# Altium schematic sheet Sheet8.SchDoc(Sheet8)
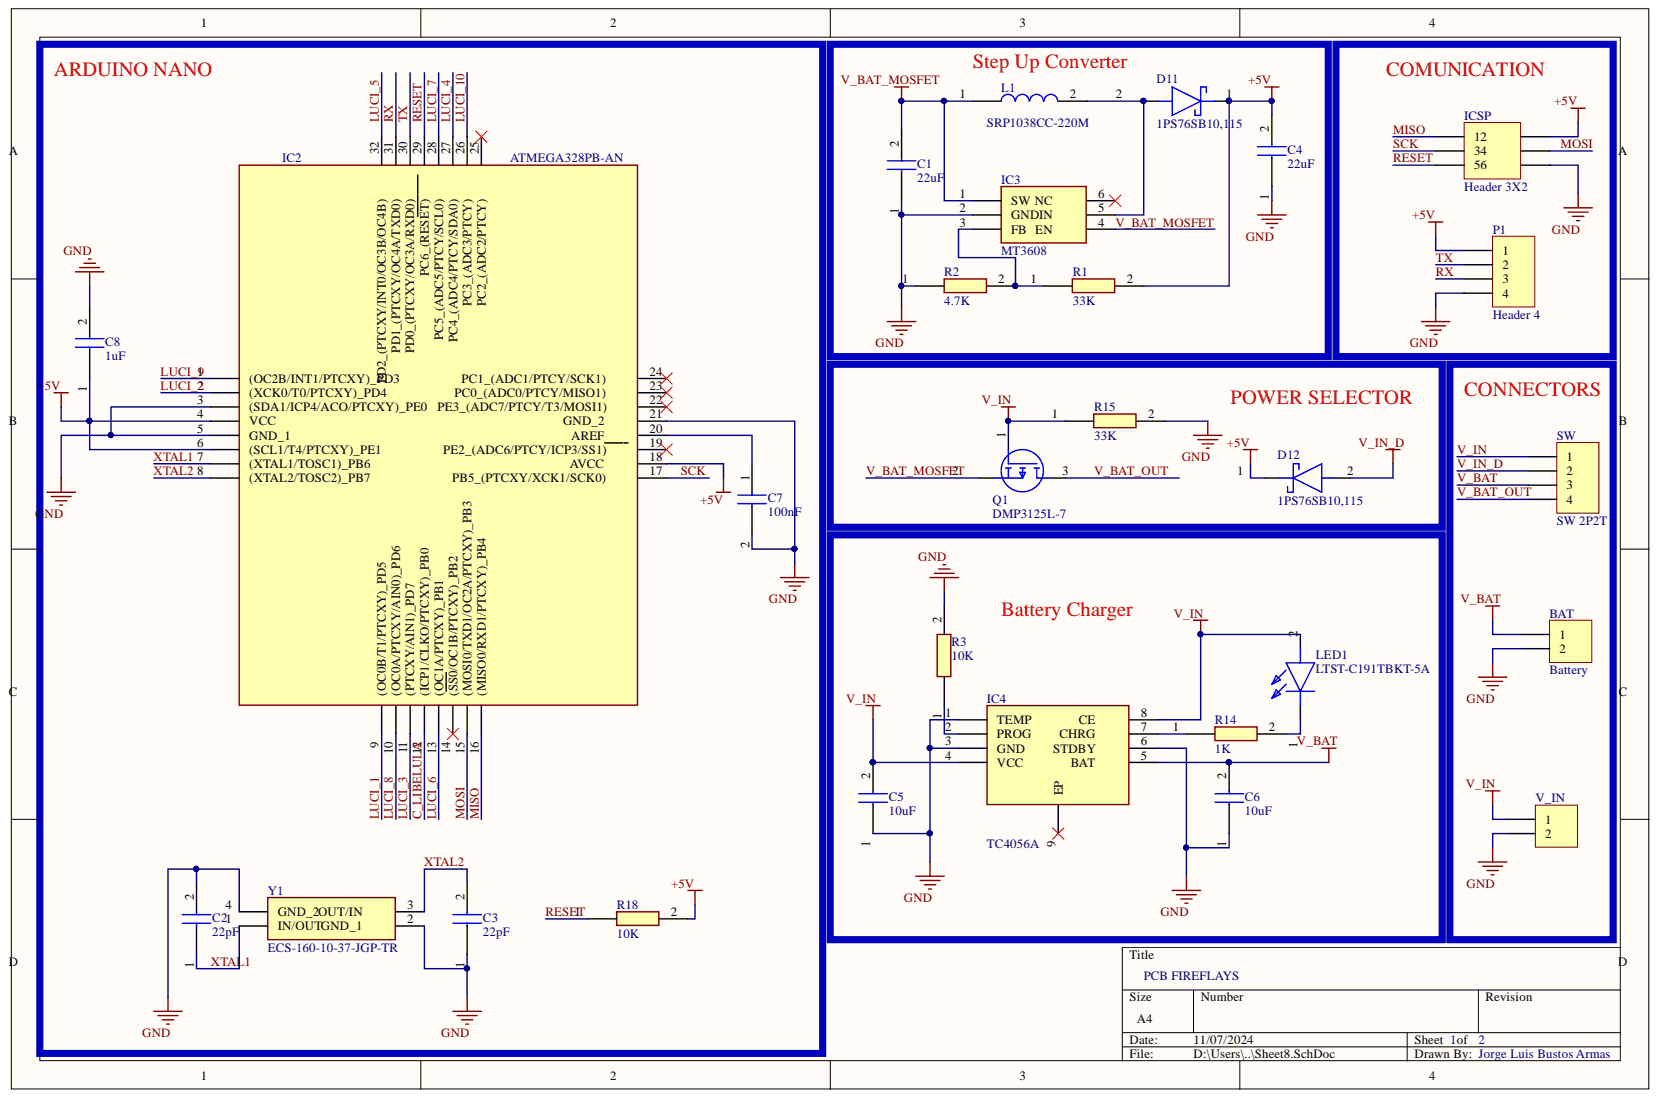
[diagram p0: Sheet8.SchDoc]

## Parts
BAT  Battery  [HDR1X2]
C1  22uF  [CAPC1608X90N]
C2  22pF  [CAPC1608X90N]
C3  22pF  [CAPC1608X90N]
C4  22uF  [CAPC1608X90N]
C5  10uF  [CAPC1608X90N]
C6  10uF  [CAPC1608X90N]
C7  100nF  [CAPC1608X90N]
C8  1uF  [CAPC1608X90N]
D11  1PS76SB10,115  [SOD2512X110N]
D12  1PS76SB10,115  [SOD2512X110N]
IC2  ATMEGA328PB-AN  [QFP80P900X900X120-32N]
IC3  MT3608  [SOT95P280X145-6N]
IC4  TC4056A  [SOIC127P600X175-9N]
ICSP  Header 3X2  [HDR2X3]  (no BOM)
L1  SRP1038CC-220M  [INDPM110100X400N]
LED1  LTST-C191TBKT-5A  [LEDC1608X60N]
P1  Header 4  [HDR1X4]  (no BOM)
Q1  DMP3125L-7  [SOT96P240X110-3N]
R1  33K  [RESC1608X55N]
R2  4.7K  [RESC1608X55N]
R3  10K  [RESC1608X55N]
R14  1K  [RESC1608X55N]
R15  33K  [RESC1608X55N]
R18  10K  [RESC1608X55N]
SW  SW 2P2T  [HDR1X4]
V_IN  Type C female connector  [HDR1X2]  (value not drawn)
Y1  ECS-160-10-37-JGP-TR  [ECS1601037JGPTR]

## Nets
+5V: C4.2 C8.1 D11.1 D12.1 IC2.4(VCC) IC2.6((SCL1/T4/PTCXY)_PE1) IC2.18 ICSP.2 P1.1 R1.2 R18.2
GND: BAT.2 C1.1 C2.2 C3.1 C4.1 C5.1 C6.1 C7.2 C8.2 IC2.3((SDA1/ICP4/ACO/PTCXY)_PE0) IC2.5(GND_1) IC2.21 IC3.2 IC4.1(TEMP) IC4.3(GND) IC4.6 ICSP.6 P1.4 R2.1 R3.2 R15.2 V_IN.2 Y1.2 Y1.4(GND_2)
C_LIBELULA: IC2.12((ICP1/CLKO/PTCXY)_PB0)
LUCI_1: IC2.9((OC0B/T1/PTCXY)_PD5)
LUCI_2: IC2.2((XCK0/T0/PTCXY)_PD4)
LUCI_3: IC2.11((PTCXY/AIN1)_PD7)
LUCI_4: IC2.27
LUCI_5: IC2.32
LUCI_6: IC2.13((OC1A/PTCXY)_PB1)
LUCI_7: IC2.28
LUCI_8: IC2.10((OC0A/PTCXY/AIN0)_PD6)
LUCI_9: IC2.1((OC2B/INT1/PTCXY)_PD3)
LUCI_10: IC2.26
MISO: IC2.16((MISO0/RXD1/PTCXY)_PB4) ICSP.1
MOSI: IC2.15((MOSI0/TXD1/OC2A/PTCXY)_PB3) ICSP.4
RESET: IC2.29 ICSP.5 R18.1
RX: IC2.31 P1.3
SCK: IC2.17 ICSP.3
TX: IC2.30 P1.2
V_BAT: BAT.1 C6.2 IC4.5 SW.3
V_BAT_MOSFET: C1.2 IC3.1(SW_NC) IC3.4 L1.1 Q1.2
V_BAT_OUT: Q1.3 SW.4
V_IN: C5.2 IC4.4(VCC) IC4.8 LED1.2 Q1.1 R15.1 SW.1 V_IN.1
V_IN_D: D12.2 SW.2
XTAL1: C2.1 IC2.7((XTAL1/TOSC1)_PB6) Y1.1(IN/OUT)
XTAL2: C3.2 IC2.8((XTAL2/TOSC2)_PB7) Y1.3
NetC7_1: C7.1 IC2.20
NetD11_2: D11.2 IC3.5 L1.2
NetIC3_3: IC3.3(FB) R1.1 R2.2
NetIC4_2: IC4.2(PROG) R3.1
NetIC4_7: IC4.7 R14.1
NetLED1_1: LED1.1 R14.2

Not connected: IC2 14((SS0/OC1B/PTCXY)_PB2) 19 22 23 24 25; IC3 6; IC4 9(EP)

## Library data of the parts
BAT = Header 2: Li-Po battery 400 mAh; Component Kind: Standard; LatestRevisionDate: 17-Jul-2002; LatestRevisionNote: Re-released for DXP Platform.; Library Name: Miscellaneous Connectors.IntLib; Signal Integrity: Connector; Publisher: Altium Limited
C1-C8 = 06035C105KAT2A: Capacitor; Manufacturer_Name: Kyocera AVX; Arrow Part Number: 06035C105KAT2A; Arrow Price/Stock: https://www.arrow.com/en/products/06035c105kat2a/avx?region=nac; Component Kind: Standard; Datasheet Link: https://componentsearchengine.com/Datasheets/1/06031A100FAT2A.pdf; Height: 0.9mm; Manufacturer_Part_Number: 06035C105KAT2A; Mouser Part Number: 581-06035C105KAT2A; Mouser Price/Stock: https://www.mouser.co.uk/ProductDetail/KYOCERA-AVX/06035C105KAT2A?qs=%252BdQmOuGyFcGCdIIWh6fU7Q%3D%3D
D11 D12 = 1PS76SB10,115: Schottky Diode; Manufacturer_Name: Nexperia; Arrow Part Number: 1PS76SB10,115; Arrow Price/Stock: https://www.arrow.com/en/products/1ps76sb10115/nexperia?region=europe; Component Kind: Standard; Datasheet Link: https://assets.nexperia.com/documents/data-sheet/1PS76SB10.pdf; Height: 1.1mm; Library Name: SamacSys.SchLib; Manufacturer_Part_Number: 1PS76SB10,115; Mouser Part Number: 771-1PS76SB10-T/R; Mouser Price/Stock: https://www.mouser.co.uk/ProductDetail/Nexperia/1PS76SB10115?qs=P62ublwmbi%252BteaMAe9dhAQ%3D%3D
IC2 = ATMEGA328PB-AN: Integrated Circuit; Manufacturer_Name: Microchip; Arrow Part Number: ATMEGA328PB-AN; Arrow Price/Stock: https://www.arrow.com/en/products/atmega328pb-an/microchip-technology?region=nac; Component Kind: Standard; Datasheet Link: http://ww1.microchip.com/downloads/en/DeviceDoc/40001906C.pdf; Height: 1.2mm; Manufacturer_Part_Number: ATMEGA328PB-AN; Mouser Part Number: 556-ATMEGA328PB-AN; Mouser Price/Stock: https://www.mouser.co.uk/ProductDetail/Microchip-Technology/ATMEGA328PB-AN?qs=jy4bLUHv09gDoS2J01KCIw%3D%3D
IC3 = MT3608: Integrated Circuit; Manufacturer_Name: Aerosemi; Component Kind: Standard; Datasheet Link: https://www.olimex.com/Products/Breadboarding/BB-PWR-3608/resources/MT3608.pdf; Height: 1.45mm; Manufacturer_Part_Number: MT3608
IC4 = TC4056A: Integrated Circuit; Manufacturer_Name: Shenzhen Fuman Elec; Component Kind: Standard; Height: 1.75mm; Library Name: SamacSys.SchLib; Manufacturer_Part_Number: TC4056A
ICSP = Header 3X2: Header, 3-Pin, Dual row; LatestRevisionDate: 17-Jul-2002; LatestRevisionNote: Re-released for DXP Platform.; Library Name: Miscellaneous Connectors.IntLib; Signal Integrity: Connector; Publisher: Altium Limited
L1 = SRP1038CC-220M: Inductor; Manufacturer_Name: Bourns; Arrow Part Number: SRP1038CC-220M; Arrow Price/Stock: https://www.arrow.com/en/products/srp1038cc-220m/bourns?region=nac; Component Kind: Standard; Datasheet Link: https://www.bourns.com/docs/Product-Datasheets/SRP1038CC.pdf; Height: 4mm; Manufacturer_Part_Number: SRP1038CC-220M; Mouser Part Number: 652-SRP1038CC-220M; Mouser Price/Stock: https://www.mouser.co.uk/ProductDetail/Bourns/SRP1038CC-220M?qs=1Kr7Jg1SGW9WsxR9tq21fg%3D%3D
LED1 = LTST-C191TBKT-5A: LED; Manufacturer_Name: Lite-On; Arrow Part Number: LTST-C191TBKT-5A; Arrow Price/Stock: https://www.arrow.com/en/products/ltst-c191tbkt-5a/lite-on-technology?region=europe; Component Kind: Standard; Datasheet Link: http://www.mouser.com/ds/2/239/S_110_LTST-C191TBKT-5A-336399.pdf; Height: 0.65mm; Library Name: SamacSys.SchLib; Manufacturer_Part_Number: LTST-C191TBKT-5A; Mouser Part Number: 859-LTST-C191TBKT-5A; Mouser Price/Stock: https://www.mouser.co.uk/ProductDetail/Lite-On/LTST-C191TBKT-5A?qs=xb8aMrBSZRLgwF8AXHEbeQ%3D%3D
P1 = Header 4: Header, 4-Pin; LatestRevisionDate: 17-Jul-2002; LatestRevisionNote: Re-released for DXP Platform.; Library Name: Miscellaneous Connectors.IntLib; Signal Integrity: Connector; Publisher: Altium Limited
Q1 = DMP3125L-7: MOSFET (P-Channel); Manufacturer_Name: Diodes Incorporated; Arrow Part Number: DMP3125L-7; Arrow Price/Stock: https://www.arrow.com/en/products/dmp3125l-7/diodes-incorporated?region=nac; Component Kind: Standard; Datasheet Link: https://www.diodes.com//assets/Datasheets/DMP3125L.pdf; Height: 1.1mm; Library Name: SamacSys.SchLib; Manufacturer_Part_Number: DMP3125L-7; Mouser Part Number: 621-DMP3125L-7; Mouser Price/Stock: https://www.mouser.co.uk/ProductDetail/Diodes-Incorporated/DMP3125L-7?qs=W0yvOO0ixfH8ma8MdHfXQA%3D%3D
R1-R3 R14 R15 R18 = KTR03EZPF1501: Resistor; Manufacturer_Name: ROHM Semiconductor; Component Kind: Standard; Datasheet Link: http://rohmfs.rohm.com/en/products/databook/datasheet/passive/resistor/chip_resistor/ktr.pdf; Height: 0.55mm; Library Name: SamacSys.SchLib; Manufacturer_Part_Number: KTR03EZPF1501; Mouser Part Number: 755-KTR03EZPF1501; Mouser Price/Stock: https://www.mouser.com/Search/Refine.aspx?Keyword=755-KTR03EZPF1501
SW = Header 4: SWITCH, 2 POLES 2 THROWS; Component Kind: Standard; LatestRevisionDate: 17-Jul-2002; LatestRevisionNote: Re-released for DXP Platform.; Library Name: Miscellaneous Connectors.IntLib; Signal Integrity: Connector; Publisher: Altium Limited
V_IN = Header 2: USB Type C 2 Pins Connector Jack Female Type-C 3A 30V Oval with Wire Current Fast Charging Jacker Port USB-C Charger Plug Socket; Component Kind: Standard; LatestRevisionDate: 17-Jul-2002; LatestRevisionNote: Re-released for DXP Platform.; Library Name: Miscellaneous Connectors.IntLib; Signal Integrity: Connector; Supplier: https://www.aliexpress.com/item/1005006809102590.html?gatewayAdapt=glo2esp; Publisher: Altium Limited
Y1 = ECS-160-10-37-JGP-TR: Crystal or Oscillator; Manufacturer_Name: ECS; Component Kind: Standard; Datasheet Link: https://www.ecsxtal.com/store/pdf/ecx-1637.pdf; Height: 0.55mm; Manufacturer_Part_Number: ECS-160-10-37-JGP-TR; Mouser Part Number: 520-160-10-37-JGP-TR; Mouser Price/Stock: https://www.mouser.co.uk/ProductDetail/ECS/ECS-160-10-37-JGP-TR?qs=P%2FxahI%252BVehlv%252Bk6jlp6C5A%3D%3D
